# Altium schematic sheet PressureSensor.SchDoc
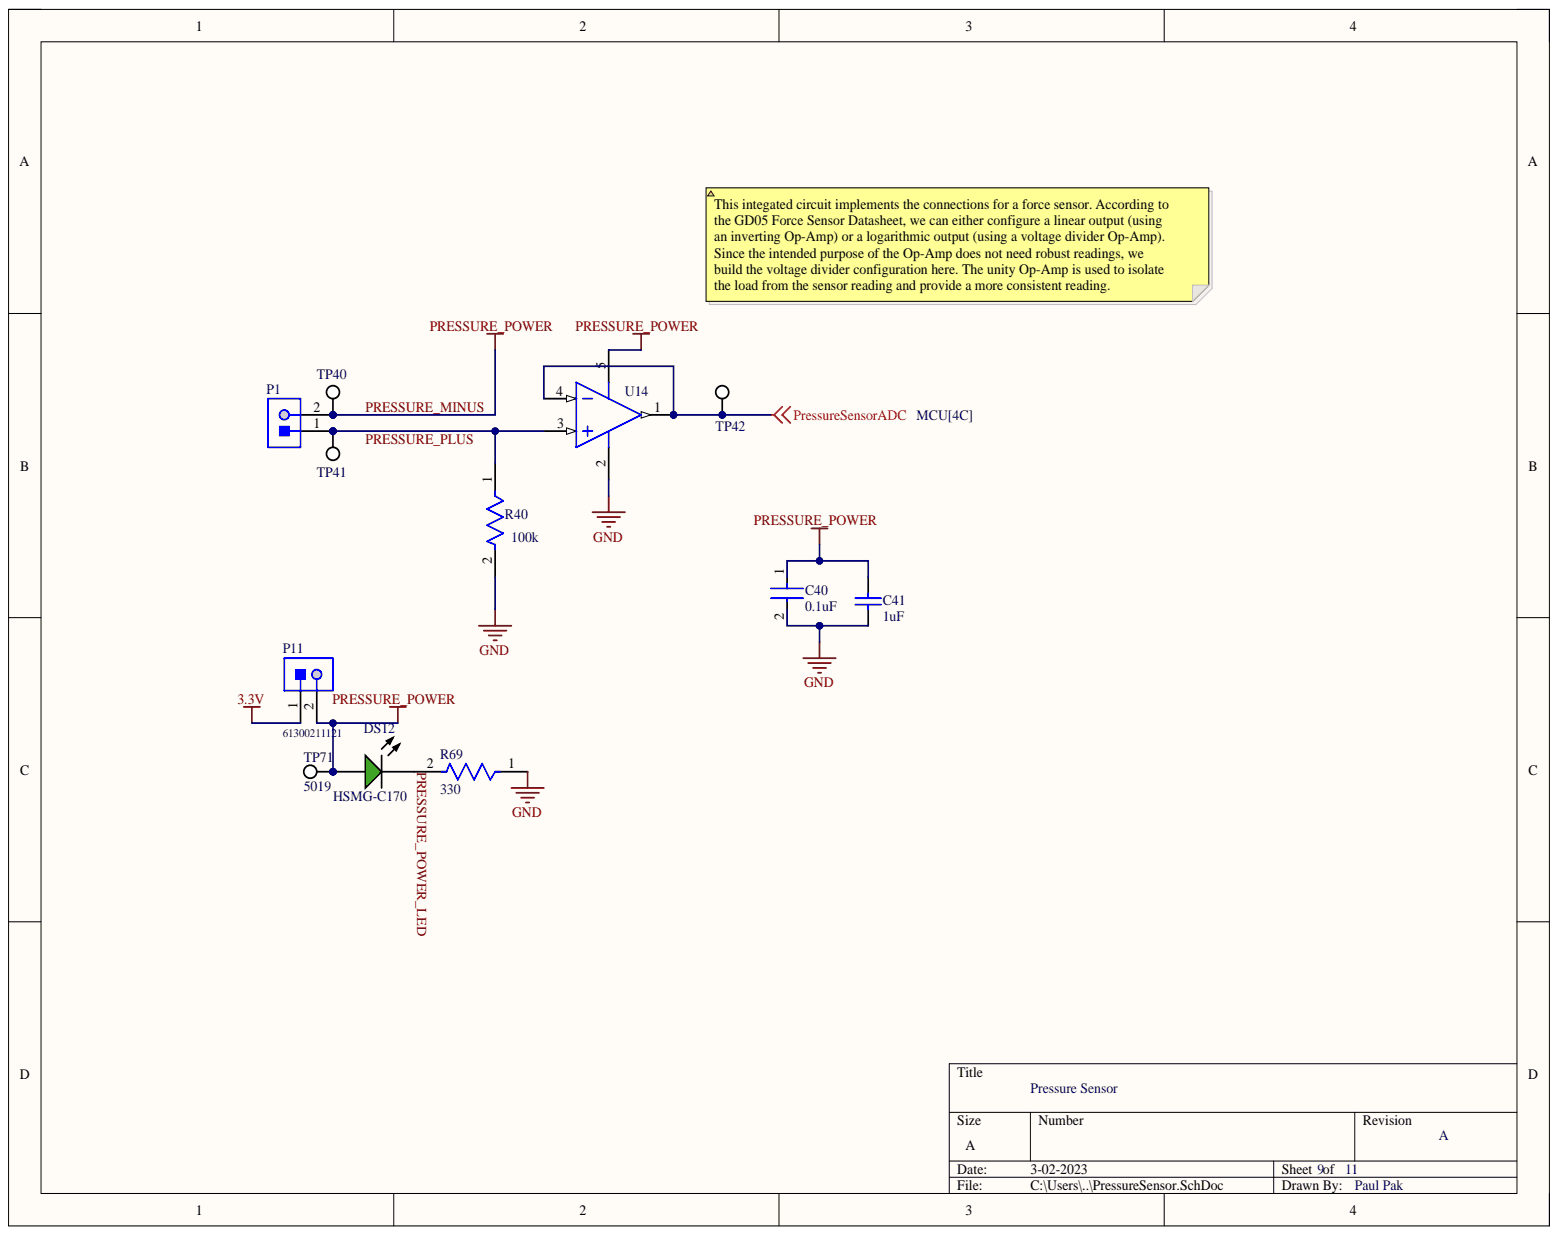
[diagram p0: PressureSensor.SchDoc]

## Parts
C40  0.1uF  [FP-CC0805-0_85-MFG]
C41  1uF  [CAPC2013X145X50ML20T25]
DS12  HSMG-C170  [AVAG-HSMX-C170_V]
P1  61300211121  [61300211121]
P11  61300211121  [61300211121]
R40  100k  [FP-ERA6A-IPC_B]
R69  330  [FP-CRCW0805-e3-MFG]
TP40  5019  [KSTN-5019_V]  (value not drawn)
TP41  5019  [KSTN-5019_V]  (value not drawn)
TP42  5019  [KSTN-5019_V]  (value not drawn)
TP71  5019  [KSTN-5019_V]
U14  LMV796QMF/NOPB  [DBV0005A_L]  (value not drawn)

## Nets
3.3V: P11.1
GND: C40.2 C41.1 R40.2 R69.1 U14.2
PressureSensorADC: TP42.1 U14.1 U14.4
PRESSURE_MINUS: C40.1 C41.2 DS12.1 P1.2 P11.2 TP40.1 TP71.1 U14.5
PRESSURE_POWER_LED: DS12.2 R69.2
NetP1_1: P1.1 R40.1 TP41.1 U14.3
PRESSURE_PLUS:

## Library data of the parts
C40 = CMP-1036-04410-2: CAP CER 0.1UF 25V X7R 0805; Manufacturer: Yageo; Manufacturer Part Number: CC0805KRX7R8BB104; Capacitance: 100 nF; Insulation Resistance: 100GR; Material: Ceramic; Tolerance: 0.1; Value: 100 nF; Automotive: No; Case Code (Imperial): 0805; Case Code (Metric): 2012; Case/Package: 0805; Category: Cap; Component Kind: Standard; ComponentLink1Description: https://datasheet.ciiva.com/8034/70765-8034031.pdf?src-supplier=Element14; ComponentLink1URL: https://datasheet.ciiva.com/8034/70765-8034031.pdf?src-supplier=Element14; ComponentLink2Description: Datasheet; ComponentLink2URL: http://www.yageo.com/documents/recent/UPY-GPHC_Y5V_6.3V-to-50V_8.pdf; ComponentLink3Description: https://datasheet.ciiva.com/28450/1489800-28450789.pdf?src-supplier=Element14; ComponentLink3URL: https://datasheet.ciiva.com/28450/1489800-28450789.pdf?src-supplier=Element14; ComponentLink4Description: https://datasheet.ciiva.com/46430/1850775-46430166.pdf?src-supplier=Element14; ComponentLink4URL: https://datasheet.ciiva.com/46430/1850775-46430166.pdf?src-supplier=Element14; ComponentLink5Description: https://datasheet.ciiva.com/145/mlccorderingcode-145008.pdf?src-supplier=Digi-Key; ComponentLink5URL: https://datasheet.ciiva.com/145/mlccorderingcode-145008.pdf?src-supplier=Digi-Key; ComponentLink6Description: https://datasheet.ciiva.com/145/mlccorderingcode-145007.pdf?src-supplier=Digi-Key; ComponentLink6URL: https://datasheet.ciiva.com/145/mlccorderingcode-145007.pdf?src-supplier=Digi-Key; ComponentLink7Description: https://datasheet.ciiva.com/145/mlccorderingcode-145009.pdf?src-supplier=Digi-Key; ComponentLink7URL: https://datasheet.ciiva.com/145/mlccorderingcode-145009.pdf?src-supplier=Digi-Key; ComponentLink8Description: https://datasheet.ciiva.com/8034/1784427-8034030.pdf?src-supplier=Element14; ComponentLink8URL: https://datasheet.ciiva.com/8034/1784427-8034030.pdf?src-supplier=Element14; Depth: 1.25mm; Device Class L1: Passive Components; Device Class L2: Capacitors; Device Class L3: Ceramic Capacitors; Dielectric: X7R; Digikey Description: CAP CER 0.1UF 25V X7R 0805; Footprint Url: http://www.yageo.com/exep/pages/download/literatures/UPY-C_GEN_24.pdf; Halogen Free: Halogen Free; Height: 0.95mm; Ipc Land Pattern Name: CAPC200125X85; Lead Free: Yes; Length: 2mm; Library Name: Altium Content Vault; Max Operating Temperature: 125°C; Min Operating Temperature: -55°C; Mount: Surface Mount; Mouser Description: Multilayer Ceramic Capacitors MLCC - SMD/SMT 100nF 25V X7R 10%; Number of Pins: 2; Octopart: https://octopart.com/cc0805krx7r8bb104-yageo-39467766; Package: CC0805-0.85; Package Quantity: 4000; Packaging: Tape and Reel; Pricing 1: 1=0.11000, 10=0.07700, 50=0.04380, 100=0.03620, 500=0.02542, 1000=0.02104 (USD); Radiation Hardening: No; Reach SVHC Compliant: Yes; Resistor Type: Ceramic; RoHS: TRUE; RoHS Compliant: Yes; Series: CC; Stock 1: 1013369; Supplier 1: Digi-Key; Supplier Part Number 1: 311-1141-1-ND; Temperature: -30°C to +85°C; Temperature Characteristic: X7R; Temperature Range High: +85°C; Temperature Range Low: -30°C; Termination: SMD/SMT; Thickness: 0.033inch; Voltage Rating: 25 V; Voltage Rating (DC): 25V; Width: 1.25mm
C41 = CMP-1036-04757-2: Manufacturer: Yageo; Manufacturer Part Number: CC0805ZRY5V8BB105; Dielectric: Y5V; Tolerance: 80%; Voltage Rating: 25V; Capacitance: 1uF; Case Code (Imperial): 0805; Case Code (Metric): 2012; Case/Package: 0805; Category: Ceramic Capacitors; Component Kind: Standard; ComponentLink1Description: https://datasheet.ciiva.com/144/mlccorderingcode-144703.pdf?src-supplier=Digi-Key; ComponentLink1URL: https://datasheet.ciiva.com/144/mlccorderingcode-144703.pdf?src-supplier=Digi-Key; ComponentLink2Description: https://datasheet.ciiva.com/26863/getdatasheetpartid-419810-26863011.pdf?src-supplier=Verical; ComponentLink2URL: https://datasheet.ciiva.com/26863/getdatasheetpartid-419810-26863011.pdf?src-supplier=Verical; ComponentLink3Description: https://datasheet.ciiva.com/28450/1132289-28450860.pdf?src-supplier=Element14; ComponentLink3URL: https://datasheet.ciiva.com/28450/1132289-28450860.pdf?src-supplier=Element14; ComponentLink4Description: https://datasheet.ciiva.com/144/mlccorderingcode-144704.pdf?src-supplier=Digi-Key; ComponentLink4URL: https://datasheet.ciiva.com/144/mlccorderingcode-144704.pdf?src-supplier=Digi-Key; ComponentLink5Description: https://datasheet.ciiva.com/144/mlccorderingcode-144705.pdf?src-supplier=Digi-Key; ComponentLink5URL: https://datasheet.ciiva.com/144/mlccorderingcode-144705.pdf?src-supplier=Digi-Key; ComponentLink6Description: https://datasheet.ciiva.com/13208/upy-gp-np0-16v-to-50v-8-13208251.pdf?src-supplier=Mouser; ComponentLink6URL: https://datasheet.ciiva.com/13208/upy-gp-np0-16v-to-50v-8-13208251.pdf?src-supplier=Mouser; Depth: 1.25mm; Halogen Free: Halogen Free; Height: 0.85mm; Lead Free: Lead Free; Length: 2mm; Library Name: Altium Content Vault; Max Operating Temperature: 85°C; Min Operating Temperature: -30°C; Mount: Surface Mount; Package Quantity: 1; Packaging: Tape and Reel; Part Number: CC0805ZRY5V8BB105; Pricing 1: 1=0.13000, 10=0.09000, 50=0.05100, 100=0.04230, 500=0.02966, 1000=0.02456 (USD); Radiation Hardening: No; Reach SVHC Compliant: Yes; Resistor Type: Ceramic; RoHS: Yes; RoHS Compliant: Yes; Series: CC; Stock 1: 286163; Supplier 1: Digi-Key; Supplier Part Number 1: 311-1358-1-ND; Termination: SMD/SMT; Thickness: 0.033inch; Voltage Rating (DC): 25V; Weight: 0.000194oz; Width: 1.25mm
DS12 = CMP-2000-05062-1: Chip LED, Green, 2.2 V, -40 to 85 degC, 2-Pin SMD, Pb-Free, Tape and Reel; Manufacturer: Avago; Bulb Size: 1.25mmx1.4mm; Dominant Wavelength: 572nm; External Depth: 0.8mm; External Length / Height: 2mm; External Width: 1.25mm; Forward Current If: 20mA; Forward Voltage: 2.2V; Forward Voltage VF Max: 2.6V; LED Type: Standard; Lens Shape: Rectangular; Light Area Height: 1mm; Luminous Intensity: 15mcd; Luminous Intensity Min: 4mcd; No. of Pins: 2; Opto Mounting Orientation: Top; Peak Wavelength: 570nm; PIV Max: 5V; SVHC: NoSVHC(16-Jun-2014); Viewing Angle: 170deg; Component Kind: Standard; Datasheet URL: https://datasheet.ciiva.com/29280/180495-da-01-en-chip-led-hsmg-c170-gelb-gruen-29280971.pdf; Datasheet Version: Oct-08; Dominant Wavelength Typ: 572nm; Forward Current If Max: 20mA; LED Colour: Green; LED Mounting: SMD; Library Name: Altium Content Vault; Luminous Intensity Typ: 15mcd; Manufacturer URL: http://www.avagotech.com/; Mounting Type: SMD; MSL: MSL2A-4weeks; Operating Temperature Max: 85degC; Operating Temperature Min: -30degC; Operating Temperature Range: -30degCto+85degC; Opto Case Style: SMD; Package Description: 2-Pin Surface Mount Device, Body 2 x 1.25 mm, Height 0.8 mm; Package Reference: HSMX-C170; Packaging: CutTape; Peak Forward Current: 20mA; Storage Temperature Max: 85degC; Storage Temperature Min: -40degC; Voltage Vf Typ: 2.2V; Wavelength Typ: 570nm
P1 P11 = CMP-1712-00002-4: THT Vertical Pin Header WR-PHD, Pitch 2.54 mm, Single Row, 2 pins; Manufacturer: Wurth Elektronik; Connector Type: Male Pin; Contact Resistance: 20mOhm; Current Rating: 3A; Gender: Male; Number of Contacts: 2; Pitch: 2.54mm; Voltage Rating: 250V; Withstanding Voltage: 500VAC; Working Voltage: 250VAC; Category: Headers and Wire Housings; Color: Black; Component Kind: Standard; ComponentLink1Description: https://datasheet.ciiva.com/13619/6130xx11121-48870-13619345.pdf?src-supplier=Mouser; ComponentLink1URL: https://datasheet.ciiva.com/13619/6130xx11121-48870-13619345.pdf?src-supplier=Mouser; Contact Gender: Male; Contact Material: Copper Alloy,Copper; Contact Mating Length: 0.236inch; Contact Plating: Gold; Contact Type: Male Pin; Datasheet URL: https://katalog.we-online.de/em/datasheet/6130xx11121.pdf; Housing Material: PBT; Lead Free: Lead Free; Library Name: Altium Content Vault; Manufacturer Part Number: 61300211121; Manufacturer URL: http://www.we-online.de; Max Operating Temperature: 125°C; Min Operating Temperature: -40°C; Mounting Technology: THT; Number of Positions: 2; Number of Rows: 1; Operating Temperature: -40to105degC; Orientation: Vertical; Package Reference: 61300211121; Packaging: Bag; Part Number: 61300211121; Pin Number: 2; Pricing 1: 1=0.13000, 10=0.11300, 25=0.09400, 50=0.07900, 100=0.07100, 1000=0.06500 (USD); Rated Current: 3A; RoHS: Yes; RoHS Compliant: Yes; Row Number: Single; Series: WR-PHD; Supplier 1: Digi-Key; Supplier Part Number 1: 732-5315-ND; Termination: Through Hole; Publisher: WurthElektronik
  P1: Mount: Through Hole; Stock 1: 203321
  P11: Stock 1: 204500
R40 = CMP-2001-00583-2: RES 100K OHM 0.1% 1/8W 0805; Manufacturer: Panasonic; Manufacturer Part Number: ERA-6AEB104V; Max Power Dissipation: 125mW; Resistance: 100kR; Temperature Coefficient: 25ppm/°C; Voltage Rating: 100V; Case Code (Imperial): 0805; Case Code (Metric): 2012; Case/Package: 0805; Category: Chip SMD Resistors; Component Kind: Standard; ComponentLink1Description: https://datasheet.ciiva.com/11811/0900766b80beefcc-11811258.pdf?src-supplier=Rs; ComponentLink1URL: https://datasheet.ciiva.com/11811/0900766b80beefcc-11811258.pdf?src-supplier=Rs; ComponentLink2Description: https://datasheet.ciiva.com/26335/453651-da-01-en-widerstand-0805-era-26335725.pdf?src-supplier=Conrad; ComponentLink2URL: https://datasheet.ciiva.com/26335/453651-da-01-en-widerstand-0805-era-26335725.pdf?src-supplier=Conrad; ComponentLink3Description: https://datasheet.ciiva.com/48425/era-eng-tds-48425749.pdf?src-supplier=Distrelec; ComponentLink3URL: https://datasheet.ciiva.com/48425/era-eng-tds-48425749.pdf?src-supplier=Distrelec; ComponentLink4Description: https://datasheet.ciiva.com/43457/485442-43457791.pdf?src-supplier=Farnell; ComponentLink4URL: https://datasheet.ciiva.com/43457/485442-43457791.pdf?src-supplier=Farnell; ComponentLink5Description: https://datasheet.ciiva.com/46438/485442-46438215.pdf?src-supplier=Element14; ComponentLink5URL: https://datasheet.ciiva.com/46438/485442-46438215.pdf?src-supplier=Element14; ComponentLink6Description: https://datasheet.ciiva.com/22758/485442-22758155.pdf?src-supplier=Farnell; ComponentLink6URL: https://datasheet.ciiva.com/22758/485442-22758155.pdf?src-supplier=Farnell; ComponentLink7Description: https://datasheet.ciiva.com/16763/70068849-16763132.pdf?src-supplier=Allied+Electronics; ComponentLink7URL: https://datasheet.ciiva.com/16763/70068849-16763132.pdf?src-supplier=Allied+Electronics; ComponentLink8Description: https://datasheet.ciiva.com/16768/70068849-16768795.pdf?src-supplier=Allied+Electronics; ComponentLink8URL: https://datasheet.ciiva.com/16768/70068849-16768795.pdf?src-supplier=Allied+Electronics; ComponentLink9Description: https://datasheet.ciiva.com/9186/aoa0000ce26-9186703.pdf?src-supplier=Avnet; ComponentLink9URL: https://datasheet.ciiva.com/9186/aoa0000ce26-9186703.pdf?src-supplier=Avnet; ComponentLink10Description: https://datasheet.ciiva.com/16396/aoa0000ce26-16396829.pdf?src-supplier=Future+Electronics; ComponentLink10URL: https://datasheet.ciiva.com/16396/aoa0000ce26-16396829.pdf?src-supplier=Future+Electronics; ComponentLink11Description: https://datasheet.ciiva.com/46438/485442-46438216.pdf?src-supplier=Element14; ComponentLink11URL: https://datasheet.ciiva.com/46438/485442-46438216.pdf?src-supplier=Element14; ComponentLink12Description: https://datasheet.ciiva.com/22758/485442-22758153.pdf?src-supplier=Farnell; ComponentLink12URL: https://datasheet.ciiva.com/22758/485442-22758153.pdf?src-supplier=Farnell; ComponentLink13Description: https://datasheet.ciiva.com/46438/485442-46438217.pdf?src-supplier=Element14; ComponentLink13URL: https://datasheet.ciiva.com/46438/485442-46438217.pdf?src-supplier=Element14; ComponentLink14Description: https://datasheet.ciiva.com/48529/era-eng-tds-48529996.pdf?src-supplier=Distrelec; ComponentLink14URL: https://datasheet.ciiva.com/48529/era-eng-tds-48529996.pdf?src-supplier=Distrelec; Construction: Rectangular; Contact Plating: Tin; Depth: 1.25mm; Features: Automotive AEC-Q200; Height: 0.5mm; Lead Free: Lead Free; Length: 2mm; Library Name: Altium Content Vault; Max Operating Temperature: 155°C; Military Standard: Not; Min Operating Temperature: -55°C; Mount: Surface Mount; Number of Pins: 2; Number of Terminations: 2; Package Quantity: 1; Packaging: Tape and Reel; Power Rating: 125mW; Pricing 1: 1=0.36000, 10=0.30100, 100=0.11770, 500=0.07116, 1000=0.04927, 2500=0.04516 (USD); Radiation Hardening: No; REACH SVHC: No SVHC; Reach SVHC Compliant: Yes; Resistance Tolerance: 0.1%; Resistor Type: Thin Film; RoHS: Yes; RoHS Compliant: Yes; Series: ERA-6A; Size Code: 0805; Stock 1: 245000; Supplier 1: Digi-Key; Supplier Part Number 1: P100KDACT-ND; Technology: METAL FILM; Termination: Solder; Tolerance: 0.1%; Voltage Rating (DC): 100V; Width: 1.25mm
R69 = CMP-2001-03823-2: RES Thick Film, 330Ω, 1%, 0.125W, 100ppm/°C, 0805; Manufacturer: Vishay; Manufacturer Part Number: CRCW0805330RFKEA; Max Power Dissipation: 125mW; Resistance: 330R; Voltage Rating: 150V; Case Code (Imperial): 0805; Case Code (Metric): 2012; Case/Package: 0805; Category: Chip SMD Resistors; Component Kind: Standard; ComponentLink1Description: https://datasheet.ciiva.com/8944/dcrcwe3-8944773.pdf?src-supplier=Avnet; ComponentLink1URL: https://datasheet.ciiva.com/8944/dcrcwe3-8944773.pdf?src-supplier=Avnet; ComponentLink2Description: https://datasheet.ciiva.com/8944/dcrcwe3-8944776.pdf?src-supplier=Avnet; ComponentLink2URL: https://datasheet.ciiva.com/8944/dcrcwe3-8944776.pdf?src-supplier=Avnet; ComponentLink3Description: https://datasheet.ciiva.com/2495/dcrcwe3-2495803.pdf?src-supplier=Digi-Key; ComponentLink3URL: https://datasheet.ciiva.com/2495/dcrcwe3-2495803.pdf?src-supplier=Digi-Key; ComponentLink4Description: https://datasheet.ciiva.com/2495/dcrcwe3-2495804.pdf?src-supplier=Digi-Key; ComponentLink4URL: https://datasheet.ciiva.com/2495/dcrcwe3-2495804.pdf?src-supplier=Digi-Key; ComponentLink5Description: https://datasheet.ciiva.com/2495/dcrcwe3-2495805.pdf?src-supplier=Digi-Key; ComponentLink5URL: https://datasheet.ciiva.com/2495/dcrcwe3-2495805.pdf?src-supplier=Digi-Key; ComponentLink6Description: https://datasheet.ciiva.com/5545/dcrcwe3-109170-5545357.pdf?src-supplier=Mouser; ComponentLink6URL: https://datasheet.ciiva.com/5545/dcrcwe3-109170-5545357.pdf?src-supplier=Mouser; ComponentLink7Description: https://datasheet.ciiva.com/16769/70201119-16769852.pdf?src-supplier=Allied+Electronics; ComponentLink7URL: https://datasheet.ciiva.com/16769/70201119-16769852.pdf?src-supplier=Allied+Electronics; ComponentLink8Description: https://datasheet.ciiva.com/7777/1697337-7777390.pdf?src-supplier=Element14; ComponentLink8URL: https://datasheet.ciiva.com/7777/1697337-7777390.pdf?src-supplier=Element14; ComponentLink9Description: https://datasheet.ciiva.com/7777/1720486-7777573.pdf?src-supplier=Element14; ComponentLink9URL: https://datasheet.ciiva.com/7777/1720486-7777573.pdf?src-supplier=Element14; Composition: Thick Film; Construction: Rectangular; Contact Plating: Tin; Depth: 1.25mm; Features: Automotive AEC-Q200; Height: 0.02inch; Lead Free: Lead Free; Length: 2mm; Library Name: Altium Content Vault; Max Operating Temperature: 155°C; Military Standard: Not; Min Operating Temperature: -55°C; Mount: Surface Mount; Number of Terminations: 2; Package Quantity: 10; Packaging: Tape and Reel; Power Rating: 125mW; Pricing 1: 1=0.10000, 10=0.06700, 100=0.02710, 1000=0.01219, 2500=0.01058 (USD); Ratings: AEC-Q200; Resistance Tolerance: 1%; Resistor Type: Thick Film; RoHS: Yes; RoHS Compliant: Yes; Series: CRCW; Size Code: 0805; Stock 1: 1889; Supplier 1: Digi-Key; Supplier Part Number 1: 541-330CCT-ND; Temperature Coefficient: 100ppm/°C; Termination: SMD/SMT; Tolerance: 1%; Voltage Rating (DC): 150V; Weight: 0.000194oz; Width: 0.049inch
TP40-TP42 TP71 = CMP-1672-00008-1: Test Point, 1 Position SMD, RoHS, Tape and Reel; Manufacturer: Keystone Electronics; Body Material: Bronze; Plating: Silver; Category: Connectors; Component Kind: Standard; ComponentLink1Description: Manufacturer URL; ComponentLink1URL: http://www.keyelco.com/; ComponentLink2Description: Datasheet; ComponentLink2URL: http://www.keyelco.com/product-pdf.cfm?p=1357; ComponentLink3Description: https://datasheet.ciiva.com/16885/70182998-16885945.pdf?src-supplier=Allied+Electronics; ComponentLink3URL: https://datasheet.ciiva.com/16885/70182998-16885945.pdf?src-supplier=Allied+Electronics; ComponentLink4Description: https://datasheet.ciiva.com/14848/m60-2p61-14848437.pdf?src-supplier=Digi-Key; ComponentLink4URL: https://datasheet.ciiva.com/14848/m60-2p61-14848437.pdf?src-supplier=Digi-Key; ComponentLink5Description: https://datasheet.ciiva.com/14848/m60-2p61-14848438.pdf?src-supplier=Digi-Key; ComponentLink5URL: https://datasheet.ciiva.com/14848/m60-2p61-14848438.pdf?src-supplier=Digi-Key; ComponentLink6Description: https://datasheet.ciiva.com/14848/m60-2p61-14848439.pdf?src-supplier=Digi-Key; ComponentLink6URL: https://datasheet.ciiva.com/14848/m60-2p61-14848439.pdf?src-supplier=Digi-Key; ComponentLink7Description: https://datasheet.ciiva.com/16318/m60-2p61-16318395.pdf?src-supplier=Future+Electronics; ComponentLink7URL: https://datasheet.ciiva.com/16318/m60-2p61-16318395.pdf?src-supplier=Future+Electronics; Contact Material: Bronze; Contact Plating: Silver; DatasheetVersion: 03/2014; Height: 0.09inch; Lead Free: Lead Free; Library Name: Altium Content Vault; Manufacturer Part Number: 5019; Material: Bronze; Mount: Surface Mount; Mounting Technology: Surface Mount; Number of Pins: 1; Package Quantity: 1000; PackageDescription: 1-Pin Surface Mount Device, Body 3.81 x 2.03 mm; PackageReference: 5019; Packaging: Tape and Reel; Pins: 1; Pricing 1: 1=0.34000, 25=0.27360, 100=0.22340, 250=0.20064, 500=0.19152 (USD); Reach SVHC Compliant: Yes; RoHS: Yes; RoHS Compliant: Yes; RoHSCompliant: True; Series: 5019; Stock 1: 1009631; Supplier 1: Digi-Key; Supplier Part Number 1: 36-5019CT-ND; Termination: SMD/SMT
U14 = CMP-0055-01622-2: 17 MHz, Low Noise, CMOS Input, 1.8V Operational Amplifier, Automotive Grade1, 5-pin SOT-23, Pb-Free; Manufacturer: Texas Instruments; Amplifier Type: GENERAL PURPOSE; Common Mode Rejection Ratio: 80dB; CompType: Amplifiers; Gain Bandwidth Product: 17MHz; Input Bias Current: 0.1pA; Input Offset Voltage (Vos): 1.35mV; Max Supply Voltage: 5.5V; Nominal Supply Current: 1.15mA; Number of Channels: 1; Output Current: 60mA; PackageTopMarking: AD7A*XTT; PowerWise: N; Slew Rate: 11.5V/µs; Voltage Gain: 110dB; Case/Package: SOT-23-5; Category: Amplifiers - Op Amps, Buffer, Instrumentation; Component Kind: Standard; ComponentLink1Description: Datasheet URL; ComponentLink1URL: http://www.ti.com/general/docs/lit/getliterature.tsp?genericPartNumber=LMV796-Q1&fileType=pdf; ComponentLink2Description: https://datasheet.ciiva.com/6627/lmv796-6627001.pdf?src-supplier=Digi-Key; ComponentLink2URL: https://datasheet.ciiva.com/6627/lmv796-6627001.pdf?src-supplier=Digi-Key; ComponentLink3Description: https://datasheet.ciiva.com/6627/lmv796-6627005.pdf?src-supplier=Digi-Key; ComponentLink3URL: https://datasheet.ciiva.com/6627/lmv796-6627005.pdf?src-supplier=Digi-Key; ComponentLink4Description: https://datasheet.ciiva.com/6626/lmv796-6626997.pdf?src-supplier=Digi-Key; ComponentLink4URL: https://datasheet.ciiva.com/6626/lmv796-6626997.pdf?src-supplier=Digi-Key; Lead Free: Lead Free; Library Name: Altium Content Vault; Manufacturer Part Number: LMV796QMF/NOPB; Max Operating Temperature: 125°C; Min Operating Temperature: -40°C; Min Supply Voltage: 1.8V; Mount: Surface Mount; Number of Circuits: 1; Number of Elements: 1; Number of Pins: 5; Operating Supply Current: 1.15mA; Output Current per Channel: 60mA; Package Quantity: 1; Package Reference: DBV0005A; PackageDescription: Molded SOT-23, .115 x .063 x .040 in Body, 5 Lead, .0375 in Pitch; Packaging: Tape and Reel; PinCount: 5; Power Supply Rejection Ratio (PSRR): 80dB; Pricing 1: 1=2.14000, 10=1.92100, 25=1.81280, 100=1.54430, 250=1.45008, 500=1.26882 (USD); Radiation Hardening: No; RoHS: Y; RoHS Compliant: Yes; Series: PowerWise®; Stock 1: 4981; Supplier 1: Digi-Key; Supplier Part Number 1: LMV796QMF/NOPBCT-ND; Supply Current: 1.15mA; Unity Gain Bandwidth Product: 17MHz
